# Altium schematic sheet LIDO-HW.200.MCU_SWD_Reset.SchDoc(LIDO-HW.200.MCU_SWD_Reset)
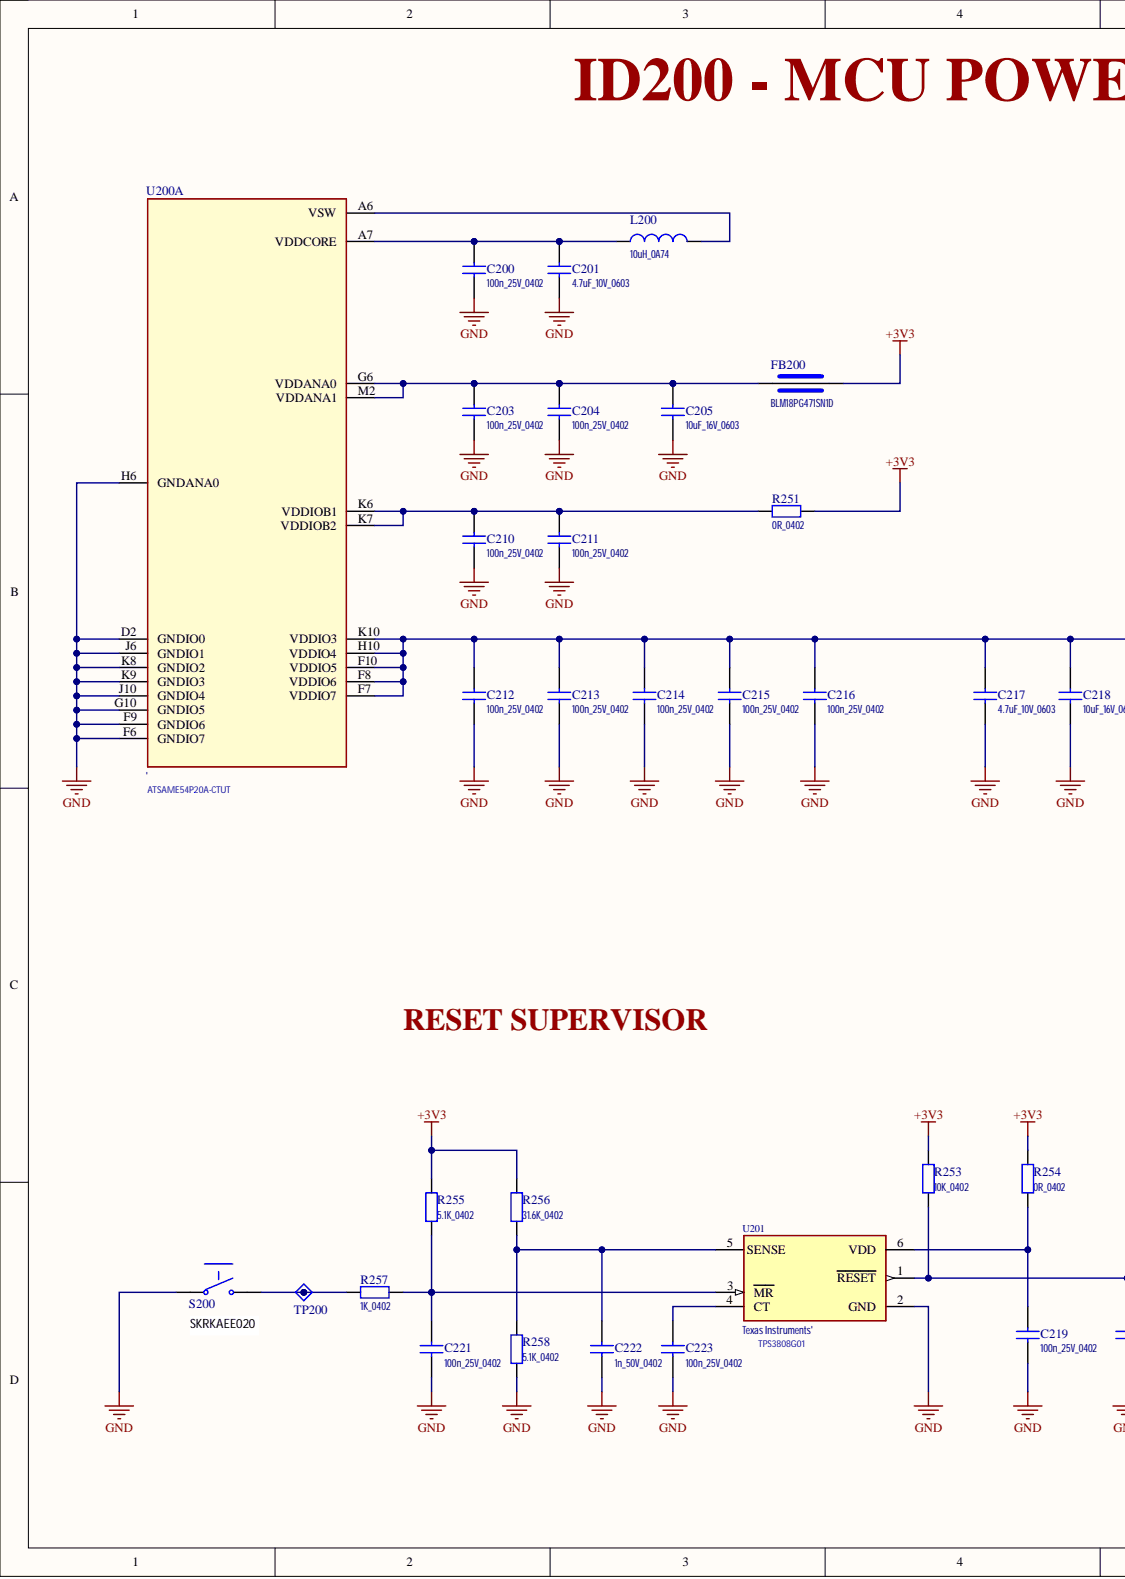
[diagram p0: LIDO-HW.200.MCU_SWD_Reset.SchDoc, left]
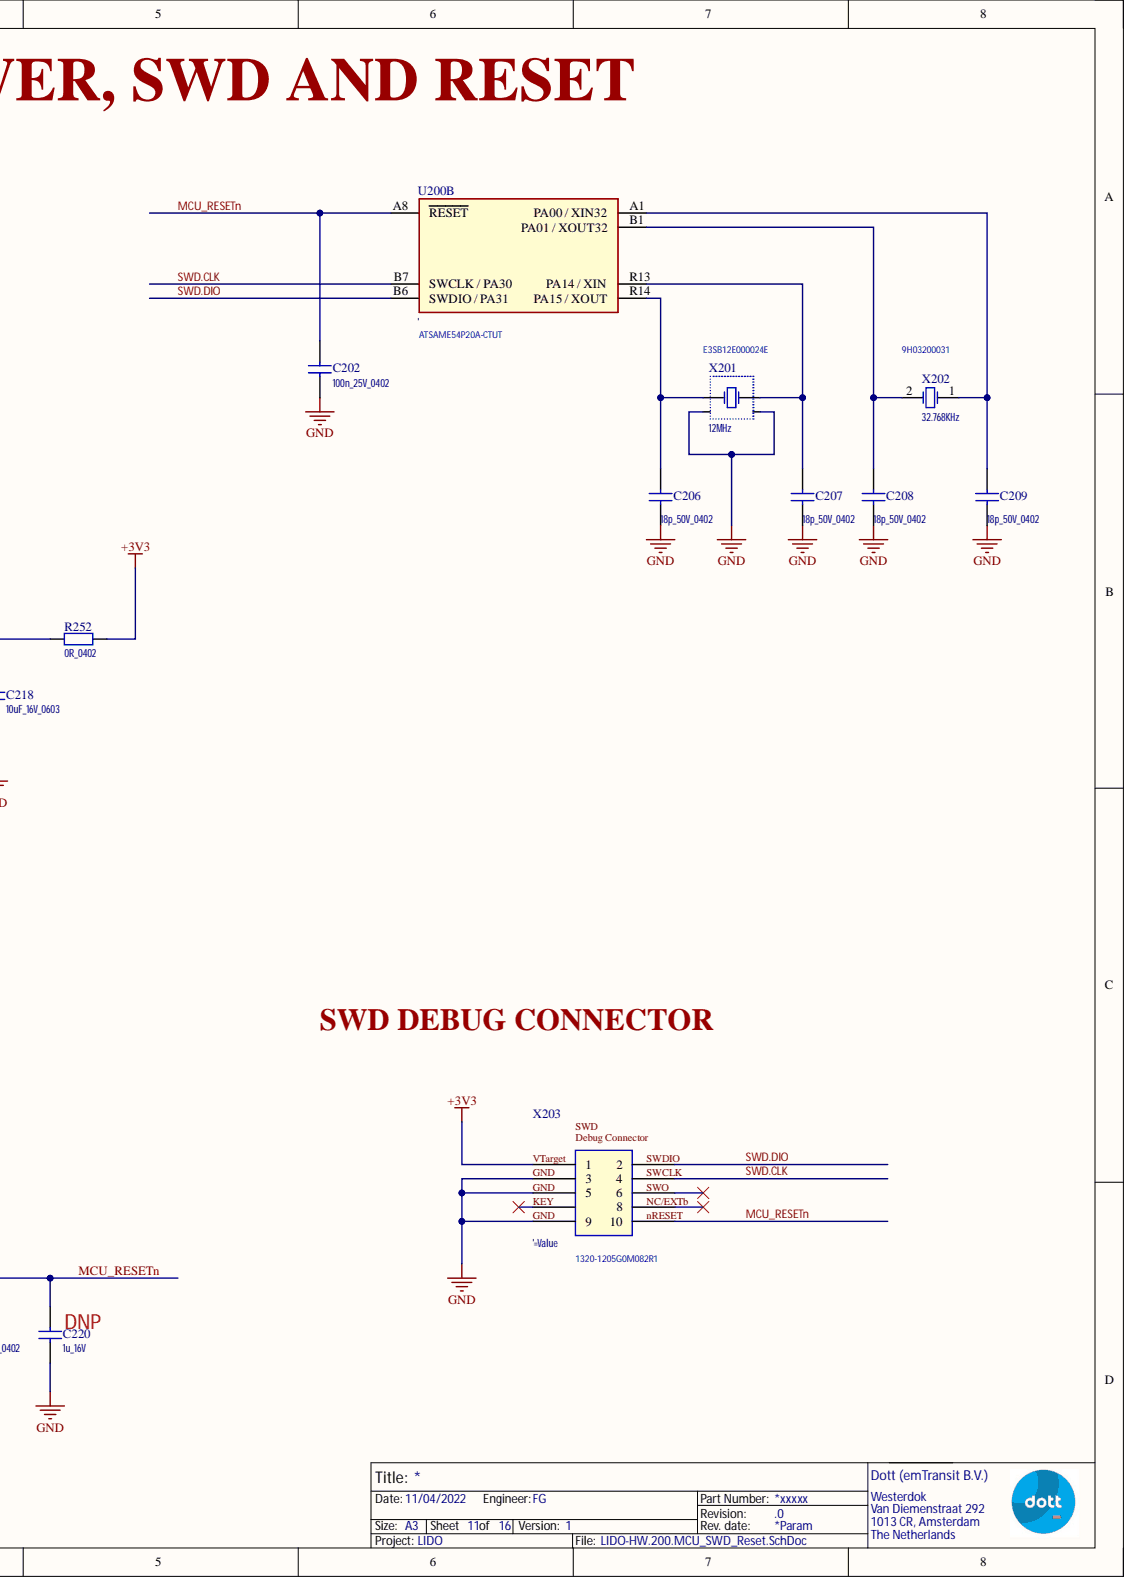
[diagram p1: LIDO-HW.200.MCU_SWD_Reset.SchDoc, right]

## Parts
C200  100n_25V_0402
C201  4.7uF_10V_0603
C202  100n_25V_0402
C203  100n_25V_0402
C204  100n_25V_0402
C205  10uF_16V_0603
C206  18p_50V_0402
C207  18p_50V_0402
C208  18p_50V_0402
C209  18p_50V_0402
C210  100n_25V_0402
C211  100n_25V_0402
C212  100n_25V_0402
C213  100n_25V_0402
C214  100n_25V_0402
C215  100n_25V_0402
C216  100n_25V_0402
C217  4.7uF_10V_0603
C218  10uF_16V_0603
C219  100n_25V_0402
C220  1u_16V
C221  100n_25V_0402
C222  1n_50V_0402
C223  100n_25V_0402
FB200  BLM18PG471SN1D
L200  10uH_0A74
R251  0R_0402
R252  0R_0402
R253  10K_0402
R254  0R_0402
R255  5.1K_0402
R256  31.6K_0402
R257  1K_0402
R258  5.1K_0402
S200  '=Value  (value not drawn)
TP200  TestPoint_A  (value not drawn)  (no BOM)
U200  '  (parts A,B)
U201  Texas Instruments'  value TPS3808G01  [SOT95P280X145-6N]
X201  12MHz
X202  32.768KHz
X203  '=Value

## Nets
+3V3: FB200.2 R251.2 R252.2 R253.2 R254.2 R255.2 R256.2 X203.1
GND: C200.2 C201.2 C202.2 C203.2 C204.2 C205.2 C206.2 C207.2 C208.2 C209.2 C210.2 C211.2 C212.2 C213.2 C214.2 C215.2 C216.2 C217.2 C218.2 C219.2 C220.2 C221.2 C222.2 C223.2 R258.1 S200.1 U200.D2(GNDIO0) U200.F6(GNDIO7) U200.F9(GNDIO6) U200.G10(GNDIO5) U200.H6(GNDANA0) U200.J6(GNDIO1) U200.J10(GNDIO4) U200.K8(GNDIO2) U200.K9(GNDIO3) U201.2(GND) X201.2 X201.4 X203.3 X203.5 X203.9
MCU_RESETn: C202.1 C220.1 R253.1 U200.A8(RESET) U201.1(RESET) X203.10
SWD.CLK: U200.B7(SWCLK_/_PA30) X203.4
SWD.DIO: U200.B6(SWDIO_/_PA31) X203.2
NetC200_1: C200.1 C201.1 L200.1 U200.A7(VDDCORE)
NetC203_1: C203.1 C204.1 C205.1 FB200.1 U200.G6(VDDANA0) U200.M2(VDDANA1)
NetC206_1: C206.1 U200.R14(PA15_/_XOUT) X201.3
NetC207_1: C207.1 U200.R13(PA14_/_XIN) X201.1
NetC208_1: C208.1 U200.B1(PA01_/_XOUT32) X202.2
NetC209_1: C209.1 U200.A1(PA00_/_XIN32) X202.1
NetC210_1: C210.1 C211.1 R251.1 U200.K6(VDDIOB1) U200.K7(VDDIOB2)
NetC212_1: C212.1 C213.1 C214.1 C215.1 C216.1 C217.1 C218.1 R252.1 U200.F7(VDDIO7) U200.F8(VDDIO6) U200.F10(VDDIO5) U200.H10(VDDIO4) U200.K10(VDDIO3)
NetC219_1: C219.1 R254.1 U201.6(VDD)
NetC221_1: C221.1 R255.1 R257.1 U201.3(MR)
NetC222_1: C222.1 R256.1 R258.2 U201.5(SENSE)
NetC223_1: C223.1 U201.4(CT)
NetL200_2: L200.2 U200.A6(VSW)
NetR257_2: R257.2 S200.2 TP200.1

Not connected: X203 6 7 8

## Library data of the parts
C200-C223 = Ceramic: Ceramic CAP Symbol; Component Kind: Standard; Library Name: 01_Capacitors.SchLib
FB200 = Ferrite Bead: Ferrite Bead Symbol; Component Kind: Standard; Library Name: 08_Filters.SchLib
L200 = Inductor: Inductor Symbol; Component Kind: Standard; Library Name: 03_Inductors_Coils_Chokes.SchLib
R251-R258 = Resistor: Resistor Symbol; Component Kind: Standard; Library Name: 02_Resistors.SchLib
S200 = TactileSwitch_SPST_NO_SINGLE: Tactile Switch SPST-NO  Type A; Component Kind: Standard; Library Name: 13_Switches.SchLib
TP200 = TestPoint_A: Test Point Symbol verion A; 00_Name: TP_XXmm; 01_Diameter: 1mm; Library Name: 31_MFG_Elements.SchLib
U200 = ATMEL_ATSAME54P20A-CT_A: 32-bit ARM® Cortex®-M4F MCUs with 1 Msps 12-bit ADC,  QSPI, USB, Ethernet, and PTC; Component Kind: Standard; Library Name: LIDO_Lib.SchLib
U201 = TI_TPS3808_A: IC SUPERVISOR 1 CHANNEL SOT23-6; Manufacturer Part Number: TPS3808G01DBVR; Designer: Matt Drazenovich; Ratings: RoHS; Reviewed: Dekimo_30-06-2020; Case/Package: SOT-23-6; Component Kind: Standard; ComponentType: IC; Design Date: 04-11-2019; Manufacturer: Texas Instruments; Max Operating Temperature: 125°C; Min Operating Temperature: -40°C; Library Name: LIDO_Lib.SchLib
X201 = XTAL_4Pins_A: Crystal with Four Pins,   X - 1 & 3  GND - 2 & 4; Component Kind: Standard; Library Name: 05_Crystals_Oscillators.SchLib
X202 = XTAL 2Pins: Crystal with two pins; Component Kind: Standard; Library Name: 05_Crystals_Oscillators.SchLib
X203 = 10Pin SWD Interface: 10Pin Serial Wire Debug Interface  header SMT; Component Kind: Standard; Library Name: 40_Connectors_Rectangular.SchLib
